# Altium schematic sheet Sch-QWA17_DIO.SchDoc(Sch-QWA17_DIO)
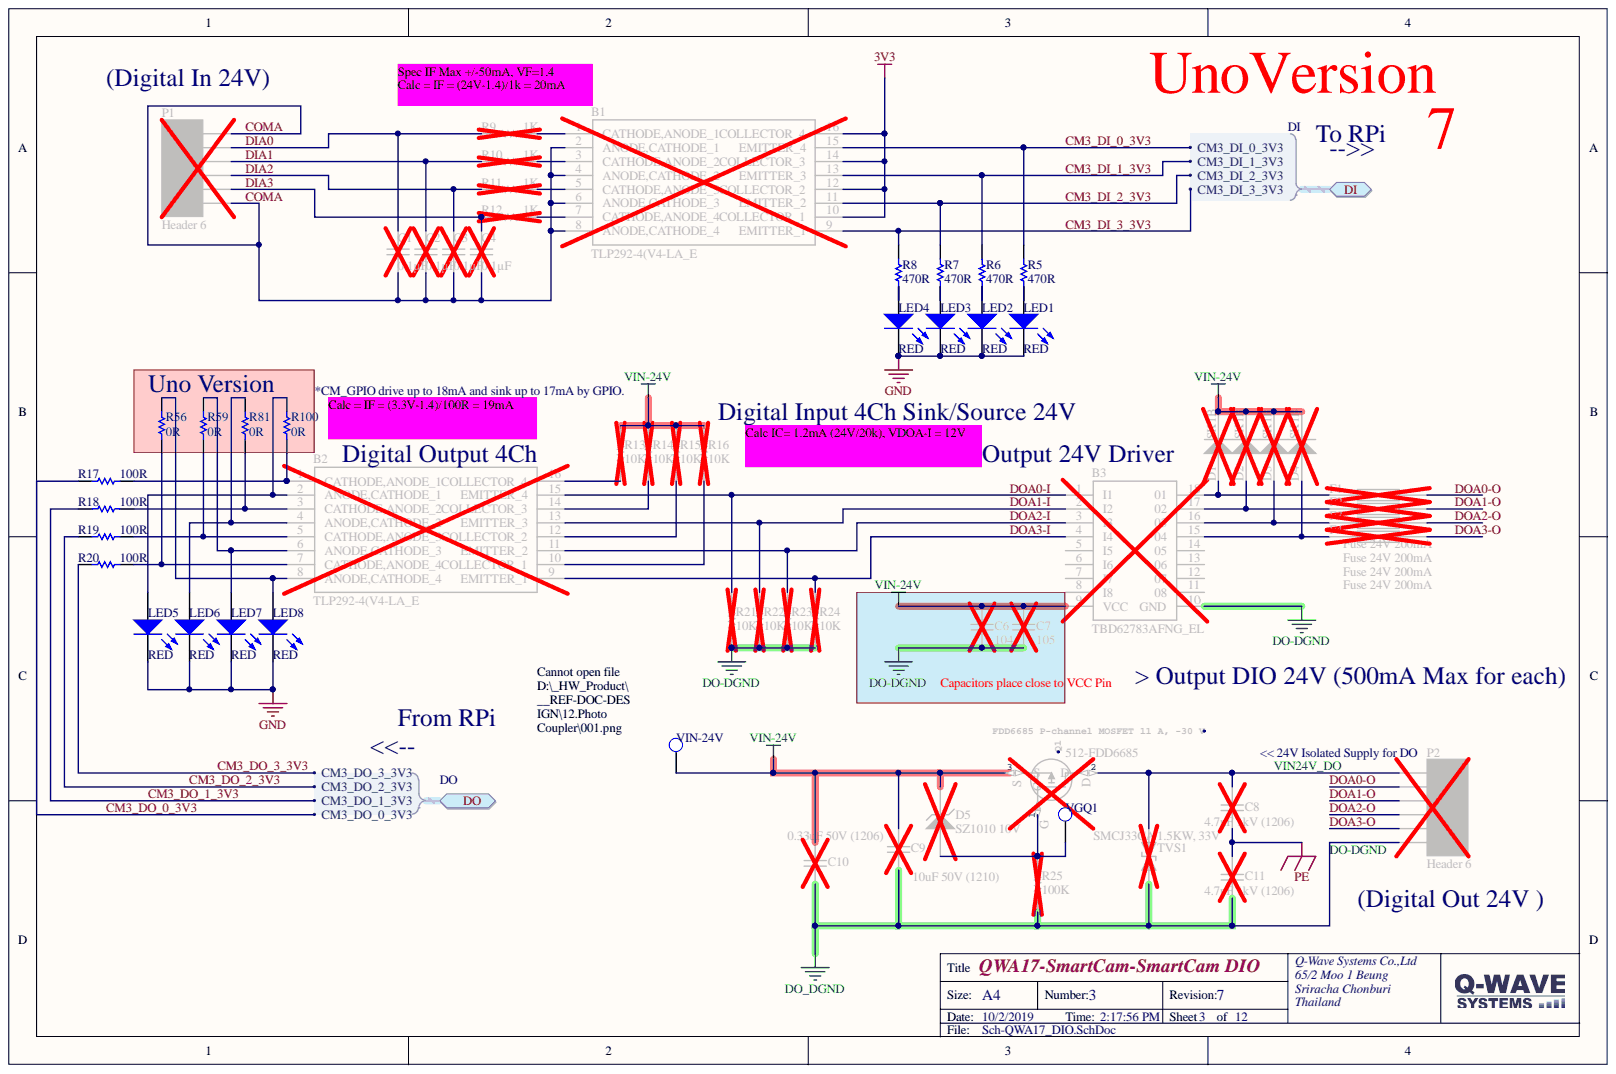
[diagram p0: Sch-QWA17_DIO.SchDoc]

## Parts
B1  TLP292-4(V4-LA_E  [SOIC127P700X230-16N]
B2  TLP292-4(V4-LA_E  [SOIC127P700X230-16N]
B3  TBD62783AFNG_EL  [SOP65P640X155-18N]
C1  0.1µF  [CAP_0603]
C2  0.1µF  [CAP_0603]
C3  0.1µF  [CAP_0603]
C4  0.1µF  [CAP_0603]
C6  104  [CAP_0603]
C7  105  [CAP_0603]
C8  4.7nF 1kV (1206)  [C 1206]
C9  10uF 50V (1210)  [C 1210]
C10  0.33uF 50V (1206)  [C 1206]
C11  4.7nF 1kV (1206)  [C 1206]
D1  SK1B  [DO-214AC_SMA]
D2  SK1B  [DO-214AC_SMA]
D3  SK1B  [DO-214AC_SMA]
D4  SK1B  [DO-214AC_SMA]
D5  SZ1010 10V  [DO-214AC_SMA]
F1  Fuse 24V 200mA  [FU 0805]
F2  Fuse 24V 200mA  [FU 0805]
F3  Fuse 24V 200mA  [FU 0805]
F4  Fuse 24V 200mA  [FU 0805]
LED1  RED  [LED_0603_RED]
LED2  RED  [LED_0603_RED]
LED3  RED  [LED_0603_RED]
LED4  RED  [LED_0603_RED]
LED5  RED  [LED_0603_RED]
LED6  RED  [LED_0603_RED]
LED7  RED  [LED_0603_RED]
LED8  RED  [LED_0603_RED]
P1  Header 6  [FCI 06-381-6PIN]
P2  Header 6  [FCI 06-381-6PIN]
Q1  FDD6685 P-channel MOSFET 11 A, -30 V  Mouser_Part_Number: 512-FDD6685  [FDD6685]
R5  470R  [RES_0603]
R6  470R  [RES_0603]
R7  470R  [RES_0603]
R8  470R  [RES_0603]
R9  1K  [RES_0603]
R10  1K  [RES_0603]
R11  1K  [RES_0603]
R12  1K  [RES_0603]
R13  10K  [RES_0603]
R14  10K  [RES_0603]
R15  10K  [RES_0603]
R16  10K  [RES_0603]
R17  100R  [RES_0603]
R18  100R  [RES_0603]
R19  100R  [RES_0603]
R20  100R  [RES_0603]
R21  10K  [RES_0603]
R22  10K  [RES_0603]
R23  10K  [RES_0603]
R24  10K  [RES_0603]
R25  100K  [RES_0603]
R56  0R  [RES_0603]
R59  0R  [RES_0603]
R81  0R  [RES_0603]
R100  0R  [RES_0603]
TVS1  SMCJ33CA 1.5KW, 33V  [DO-214AB_SMC]
VGQ1  VGQ1  [TEST_POINT]
VIN-24V  VIN-24V  [TEST_POINT]

## Ports
DI, DI.CM3_DI_0_3V3, DI.CM3_DI_1_3V3, DI.CM3_DI_2_3V3, DI.CM3_DI_3_3V3, DO, DO.CM3_DO_0_3V3, DO.CM3_DO_1_3V3, DO.CM3_DO_2_3V3, DO.CM3_DO_3_3V3

## Nets
3V3: B1.10(COLLECTOR_1) B1.12(COLLECTOR_2) B1.14(COLLECTOR_3) B1.16(COLLECTOR_4)
GND: LED1.2 LED2.2 LED3.2 LED4.2 LED5.2 LED6.2 LED7.2 LED8.2
PE: C8.2 C11.1
VIN-24V: B3.9(VCC) C6.2 C7.2 C9.1 C10.1 D1.2 D2.2 D3.2 D4.2 D5.2 Q1.3 R13.1 R14.1 R15.1 R16.1 VIN-24V.1
CM3_DI_0_3V3: B1.15(EMITTER_4) R5.1 ; port DI
CM3_DI_1_3V3: B1.13(EMITTER_3) R6.1 ; port DI
CM3_DI_2_3V3: B1.11(EMITTER_2) R7.1 ; port DI
CM3_DI_3_3V3: B1.9(EMITTER_1) R8.1 ; port DI
CM3_DO_0_3V3: R17.1 ; port DO
CM3_DO_1_3V3: R18.1 ; port DO
CM3_DO_2_3V3: R19.1 ; port DO
CM3_DO_3_3V3: R20.1 ; port DO
COMA: B1.2(ANODE,CATHODE_1) B1.4(ANODE,CATHODE_2) B1.6(ANODE,CATHODE_3) B1.8(ANODE,CATHODE_4) C1.2 C2.2 C3.2 C4.2 P1.1 P1.6
DIA0: C1.1 P1.2 R9.1
DIA1: C2.1 P1.3 R10.1
DIA2: C3.1 P1.4 R11.1
DIA3: C4.1 P1.5 R12.1
DO-DGND: B3.10(GND) C6.1 C7.1 C9.2 C10.2 C11.2 P2.6 R21.2 R22.2 R23.2 R24.2 R25.1 TVS1.1
DOA0-I: B2.15(EMITTER_4) B3.1(I1) R21.1
DOA0-O: F1.2 P2.2
DOA1-I: B2.13(EMITTER_3) B3.2(I2) R22.1
DOA1-O: F2.2 P2.3
DOA2-I: B2.11(EMITTER_2) B3.3(I3) R23.1
DOA2-O: F3.2 P2.4
DOA3-I: B2.9(EMITTER_1) B3.4(I4) R24.1
DOA3-O: F4.2 P2.5
VIN24V_DO: C8.1 P2.1 Q1.2 TVS1.2
NetB1_1: B1.1(CATHODE,ANODE_1) R9.2
NetB1_3: B1.3(CATHODE,ANODE_2) R10.2
NetB1_5: B1.5(CATHODE,ANODE_3) R11.2
NetB1_7: B1.7(CATHODE,ANODE_4) R12.2
NetB2_1: B2.1(CATHODE,ANODE_1) R17.2 R100.2
NetB2_2: B2.2(ANODE,CATHODE_1) LED5.1 R100.1
NetB2_3: B2.3(CATHODE,ANODE_2) R18.2 R81.2
NetB2_4: B2.4(ANODE,CATHODE_2) LED6.1 R81.1
NetB2_5: B2.5(CATHODE,ANODE_3) R19.2 R59.2
NetB2_6: B2.6(ANODE,CATHODE_3) LED7.1 R59.1
NetB2_7: B2.7(CATHODE,ANODE_4) R20.2 R56.2
NetB2_8: B2.8(ANODE,CATHODE_4) LED8.1 R56.1
NetB2_10: B2.10(COLLECTOR_1) R16.2
NetB2_12: B2.12(COLLECTOR_2) R15.2
NetB2_14: B2.14(COLLECTOR_3) R14.2
NetB2_16: B2.16(COLLECTOR_4) R13.2
NetB3_15: B3.15 D4.1 F4.1
NetB3_16: B3.16 D3.1 F3.1
NetB3_17: B3.17 D2.1 F2.1
NetB3_18: B3.18 D1.1 F1.1
NetD5_1: D5.1 Q1.1 R25.2 VGQ1.1
NetLED1_1: LED1.1 R5.2
NetLED2_1: LED2.1 R6.2
NetLED3_1: LED3.1 R7.2
NetLED4_1: LED4.1 R8.2

Not connected: B3 5(I5) 6(I6) 7(I7) 8(I8) 11 12 13 14

## Library data of the parts
B1 B2 = TLP292-4(V4-LA_E: Transistor Output Optocouplers 4ch Transistor opto coupler; Manufacturer_Name: Toshiba; Manufacturer_Part_Number: TLP292-4(V4-LA,E; Geometry.Height: 2.3mm; Arrow Part Number: TLP292-4(V4-LA,E; Arrow Price/Stock: https://www.arrow.com/en/products/tlp292-4-v4-lae/toshiba; Component Kind: Standard; Datasheet Link: https://toshiba.semicon-storage.com/info/docget.jsp?did=15284&prodName=TLP292-4; Library Name: TLP292-4(V4-LA_E.SchLib
B3 = TBD62783AFNG_EL: Gate Drivers DMOS Transistor Array 7-CH, 50V/0.5A; Manufacturer_Name: Toshiba; Manufacturer_Part_Number: TBD62783AFNG,EL; Geometry.Height: 1.55mm; Arrow Part Number: TBD62783AFNG,EL; Arrow Price/Stock: https://www.arrow.com/en/products/tbd62783afngel/toshiba; Component Kind: Standard; Datasheet Link: https://toshiba.semicon-storage.com/info/docget.jsp?did=30523; Library Name: TBD62783AFNG_EL.SchLib
C1-C4 C6 = Cap Semi: 0.1µF ±5% 50V Ceramic Capacitor X7R 0603; MFG: KEMET; MFG P/N: C0603C104J5RACTU; Component Kind: Standard; Library Name: Miscellaneous Devices.IntLib; Simulation: CAP
C7 = Cap Semi: 1µF ±5% 50V Ceramic Capacitor X7R 0603; MFG: KEMET; MFG P/N: C0603C104J5RACTU; Component Kind: Standard; Library Name: Miscellaneous Devices.IntLib; Simulation: CAP
C8 C11 = Cap: 4.7nF 1kV (1206) GRM31BR73A472KW01L; ES PN: 0160-0357-1; MFG: KEMET; Component Kind: Standard; ES Link: https://www.es.co.th/detail.asp?Prod=016003571; Library Name: Miscellaneous Devices.IntLib; MFG P/N: GRM31BR73A472KW01L; Simulation: CAP
C9 = Cap: 10uF 50V Ceramic Capacitor X5R 1210; MFG: KEMET; MFG P/N: C0603C475K8PACTU; Component Kind: Standard; Library Name: Miscellaneous Devices.IntLib; Simulation: CAP
C10 = Cap: 0.33uF 50V  Ceramic Capacitor X5R 1206; ES P/N: 0092-0317-6; Component Kind: Standard; Library Name: Miscellaneous Devices.IntLib; Simulation: CAP
D1-D4 = Diode: SK1B SCHOTTKY BARRIER DIODE 100V/1A; Part: EDIS005; Value: 6.05; Vender: stock; Code_JEDEC: DO-214-AB; Component Kind: Standard; LatestRevisionDate: 17-Jul-2002; LatestRevisionNote: Re-released for DXP Platform.; Library Name: Miscellaneous Devices.IntLib; MFG P/N: SK1B; PackageDocument: Sep-1996; PackageReference: SMC; Published: 8-Jun-2000; Simulation: DIODE; Supplier1: ES; Supplier_Part_Number: 863-MBRA340T3G; Supplier_Purchase_URL: https://www.es.co.th/detail.asp?Prod=035300128; Publisher: Altium Limited
D5 = D Zener: SZ1010 10V/1W, Iz=25mA; ES PN: 0353-0037-1; Component Kind: Standard; Es Link: https://www.es.co.th/detail.asp?Prod=035300371; LatestRevisionDate: 17-Jul-2002; LatestRevisionNote: Re-released for DXP Platform.; Library Name: Miscellaneous Devices.IntLib; PackageDescription: Diode, Thru-Hole; 2 Leads; 0.7 in Pin Spacing; PackageReference: DIODE-0.7; Published: 8-Jun-2000; Simulation: ZENER; Publisher: Altium Limited
F1-F4 = Fuse 1: Poly Switch PTC Resettable Fuse 24VDC/0.20A ,Tip 420mA  NANOSMDC020F-2; ES PN: 0214-0131-4; Component Kind: Standard; ES Link: https://www.es.co.th/detail.asp?Prod=021401314; LatestRevisionDate: 17-Jul-2002; LatestRevisionNote: Re-released for DXP Platform.; Library Name: Miscellaneous Devices.IntLib; PackageDescription: Thru-Hole; 2 Leads; PackageReference: PIN-W2/E2.8; Published: 8-Jun-2000; Simulation: FUSE; Publisher: Altium Limited
LED1-LED8 = LED2: LED BLUE 0603; MFG: LITE-ON; MFG P/N: LTST-C193TBKT-5A; Component Kind: Standard; Library Name: Miscellaneous Devices.IntLib; Simulation: LED2
P1 P2 = Header 6: Header, 6-Pin; Component Kind: Standard; LatestRevisionDate: 17-Jul-2002; LatestRevisionNote: Re-released for DXP Platform.; Library Name: Miscellaneous Connectors.IntLib; Publisher: Altium Limited
Q1 = FDD6685: Fairchild FDD6685 P-channel MOSFET Transistor, 11 A, -30 V, 3-Pin TO-252   Source:  Datasheet; Manufacturer_Name: Fairchild Semiconductor; ES P/N: 0123-0628-8; GateName_1: G$1; Component Kind: Standard; DeviceSetName: FDD6685; ES Link: https://www.es.co.th/detail.asp?Prod=012306288; Height: mm; Library Name: FDD6685.IntLib; LibraryName: FDD6685; Manufacturer_Part_Number: FDD6685; Mouser_Price-Stock: https://www.mouser.com/Search/Refine.aspx?Keyword=512-FDD6685; RS_Part_Number: 8090916; RS_Price-Stock: http://uk.rs-online.com/web/p/products/8090916; Supplier 1: Mouser; Supplier Part Number 1: 512-FDD6685; SymbolName_1: FDD6685
R5-R8 = Res Semi: 470R Resistor 0603; Component Kind: Standard; Library Name: Miscellaneous Devices.IntLib; Simulation: RES
R9-R25 R56 R59 R81 R100 = Res Semi: Resistor 0603; Component Kind: Standard; Library Name: Miscellaneous Devices.IntLib; Simulation: RES
TVS1 = TVS: TVS 1500W, 33V; ES PN: 0235-0490-1; Component Kind: Standard; ES Link: https://www.es.co.th/detail.asp?Prod=023504901; Library Name: Components.IntLib
VGQ1 VIN-24V = TEST_POINT: Test Point; Component Kind: Standard; Library Name: Misc.SCHLIB
